# Altium schematic sheet H_Bridge.SchDoc
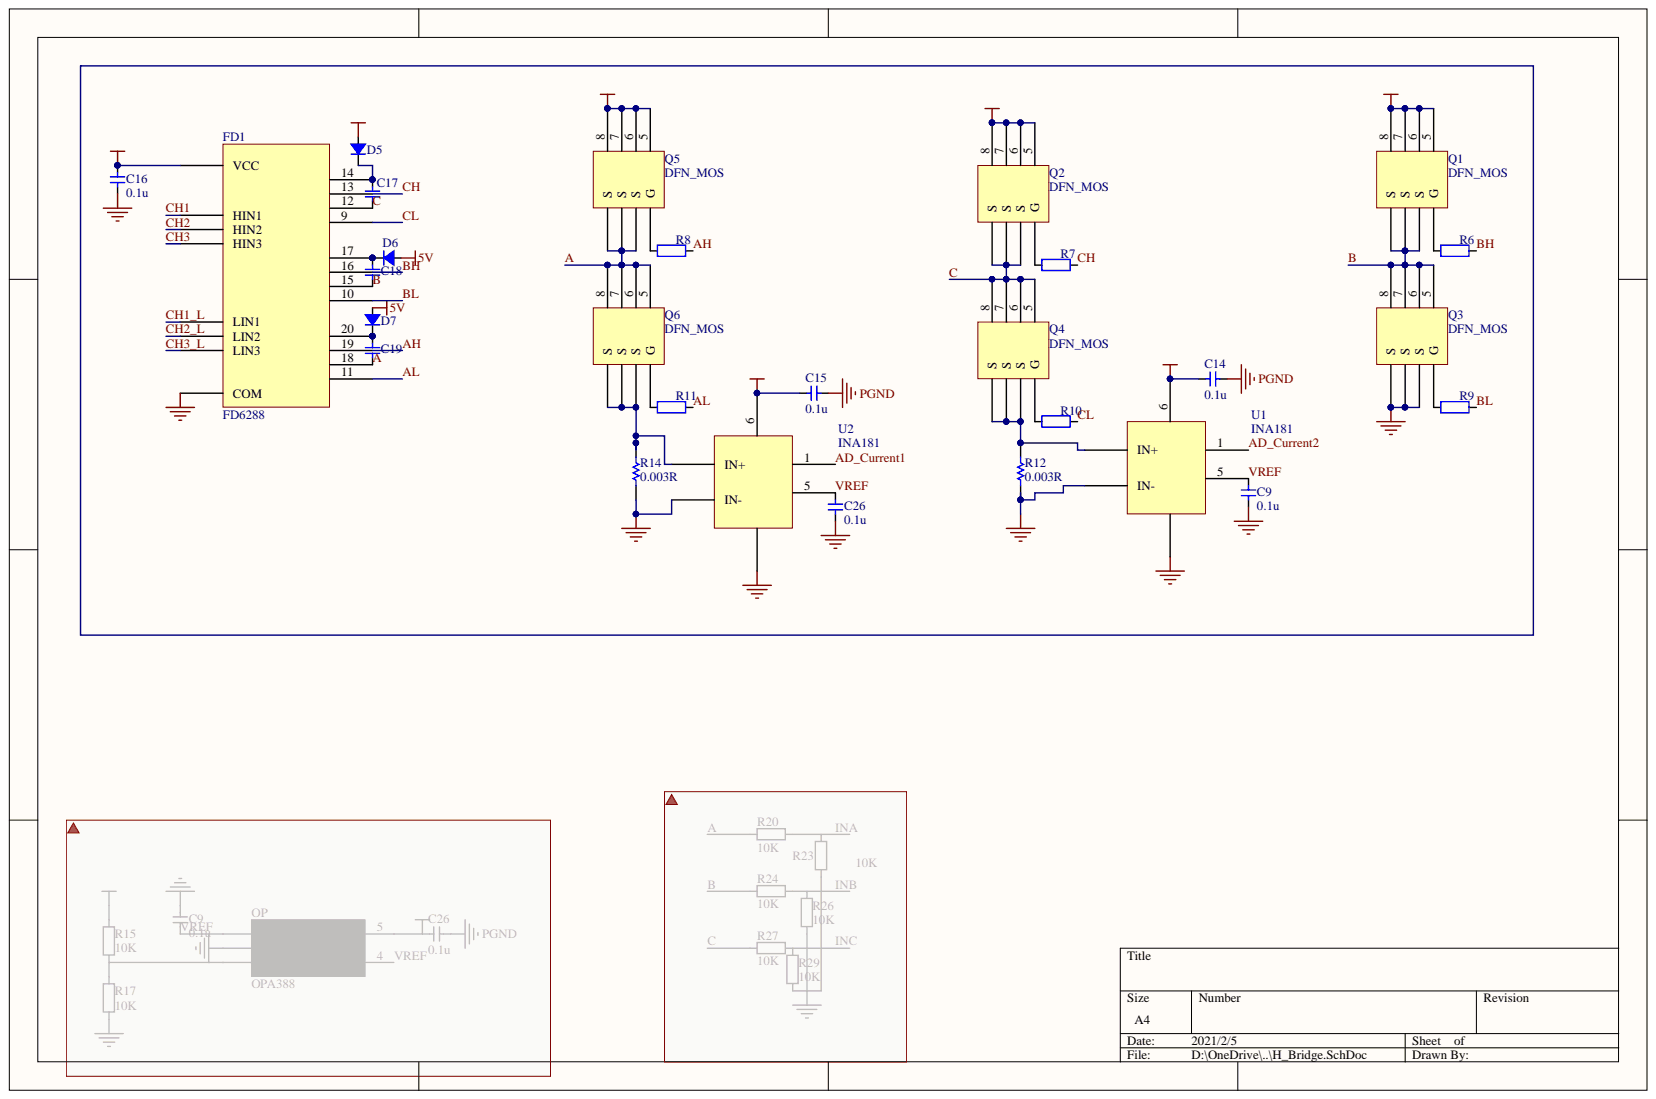
[diagram p0: H_Bridge.SchDoc]

## Parts
C9  value 0.1u  [CAP_0603]  (hidden comment: Cap_0603)
C14  value 0.1u  [CAP_0603]  (hidden comment: Cap_0603)
C15  value 0.1u  [CAP_0603]  (hidden comment: Cap_0603)
C16  value 0.1u  [CAP_0603]  (hidden comment: Cap_0603)
C17  Cap_0603  [CAP_0603]  (value not drawn)
C18  Cap_0603  [CAP_0603]  (value not drawn)
C19  Cap_0603  [CAP_0603]  (value not drawn)
C26  value 0.1u  [CAP_0603]  (hidden comment: Cap_0603)
D5  D_0805  [LED_0805_YELLOW]  (value not drawn)
D6  D_0805  [LED_0805_YELLOW]  (value not drawn)
D7  D_0805  [LED_0805_YELLOW]  (value not drawn)
FD1  FD6288  [TSSOP_E170_P0.65_20]
Q1  DFN_MOS  [DFN3X3X8]
Q2  DFN_MOS  [DFN3X3X8]
Q3  DFN_MOS  [DFN3X3X8]
Q4  DFN_MOS  [DFN3X3X8]
Q5  DFN_MOS  [DFN3X3X8]
Q6  DFN_MOS  [DFN3X3X8]
R6  Res_0603  [RES_0603]  (value not drawn)
R7  Res_0603  [RES_0603]  (value not drawn)
R8  Res_0603  [RES_0603]  (value not drawn)
R9  Res_0603  [RES_0603]  (value not drawn)
R10  Res_0603  [RES_0603]  (value not drawn)
R11  Res_0603  [RES_0603]  (value not drawn)
R12  value 0.003R  [RES-1206]  (hidden comment: Res1)
R14  value 0.003R  [RES-1206]  (hidden comment: Res1)
U1  INA181  [SOT23-6]
U2  INA181  [SOT23-6]

## Nets
5V: C14.2 C15.2 C16.2 D5.1 D6.1 D7.1 FD1.7(VCC) U1.6 U2.6
PGND: C9.2 C14.1 C15.1 C16.1 C26.2 FD1.8(COM) Q3.1(S) Q3.2(S) Q3.3(S) R12.1 R14.1 U1.2 U1.4(IN-) U2.2 U2.4(IN-)
VIN: Q1.5 Q1.6 Q1.7 Q1.8 Q2.5 Q2.6 Q2.7 Q2.8 Q5.5 Q5.6 Q5.7 Q5.8
A: C19.2 FD1.18 Q5.1(S) Q5.2(S) Q5.3(S) Q6.5 Q6.6 Q6.7 Q6.8
AD_Current1: U2.1
AD_Current2: U1.1
AH: FD1.19 R8.2
AL: FD1.11 R11.2
B: C18.2 FD1.15 Q1.1(S) Q1.2(S) Q1.3(S) Q3.5 Q3.6 Q3.7 Q3.8
BH: FD1.16 R6.2
BL: FD1.10 R9.2
C: C17.2 FD1.12 Q2.1(S) Q2.2(S) Q2.3(S) Q4.5 Q4.6 Q4.7 Q4.8
CH: FD1.13 R7.2
CH1: FD1.1(HIN1)
CH1_L: FD1.4(LIN1)
CH2: FD1.2(HIN2)
CH2_L: FD1.5(LIN2)
CH3: FD1.3(HIN3)
CH3_L: FD1.6(LIN3)
CL: FD1.9 R10.2
VREF: C9.1 C26.1 U1.5 U2.5
NetC17_1: C17.1 D5.2 FD1.14
NetC18_1: C18.1 D6.2 FD1.17
NetC19_1: C19.1 D7.2 FD1.20
NetQ1_4: Q1.4(G) R6.1
NetQ2_4: Q2.4(G) R7.1
NetQ3_4: Q3.4(G) R9.1
NetQ4_1: Q4.1(S) Q4.2(S) Q4.3(S) R12.2 U1.3(IN+)
NetQ4_4: Q4.4(G) R10.1
NetQ5_4: Q5.4(G) R8.1
NetQ6_1: Q6.1(S) Q6.2(S) Q6.3(S) R14.2 U2.3(IN+)
NetQ6_4: Q6.4(G) R11.1
N00097:

## Library data of the parts
C9 C14-C16 C26 = Cap_0603: Capacitor; Component Kind: Standard; Library Name: SCH_Passive.SchLib
C17-C19 = Cap_0603: Capacitor; Value: 0.1u; Component Kind: Standard; Library Name: SCH_Passive.SchLib
R6-R11 = Res_0603: Resistor; Value: 10R; Component Kind: Standard; Library Name: SCH_Passive.SchLib
R12 R14 = Res1: Resistor; Component Kind: Standard; LatestRevisionDate: 17-Jul-2002; LatestRevisionNote: Re-released for DXP Platform.; Library Name: K60-mainboard-v3.1.SCHLIB; PackageReference: AXIAL-0.3; Published: 8-Jun-2000; Signal Integrity: Res; Simulation: RESISTOR; Publisher: Altium Limited
D5-D7 = D_0805: Component Kind: Standard; Library Name: SCH_Active.SchLib
FD1 = FD6288: Component Kind: Standard; Library Name: SCH_IntegratedCircuit.SchLib
Q1-Q6 = DFN_MOS: Component Kind: Standard; Library Name: SCH_Active.SchLib
U1 U2 = INA181: Component Kind: Standard; Library Name: SCH_IntegratedCircuit.SchLib
